# Altium schematic sheet STM32.SchDoc(STM32)
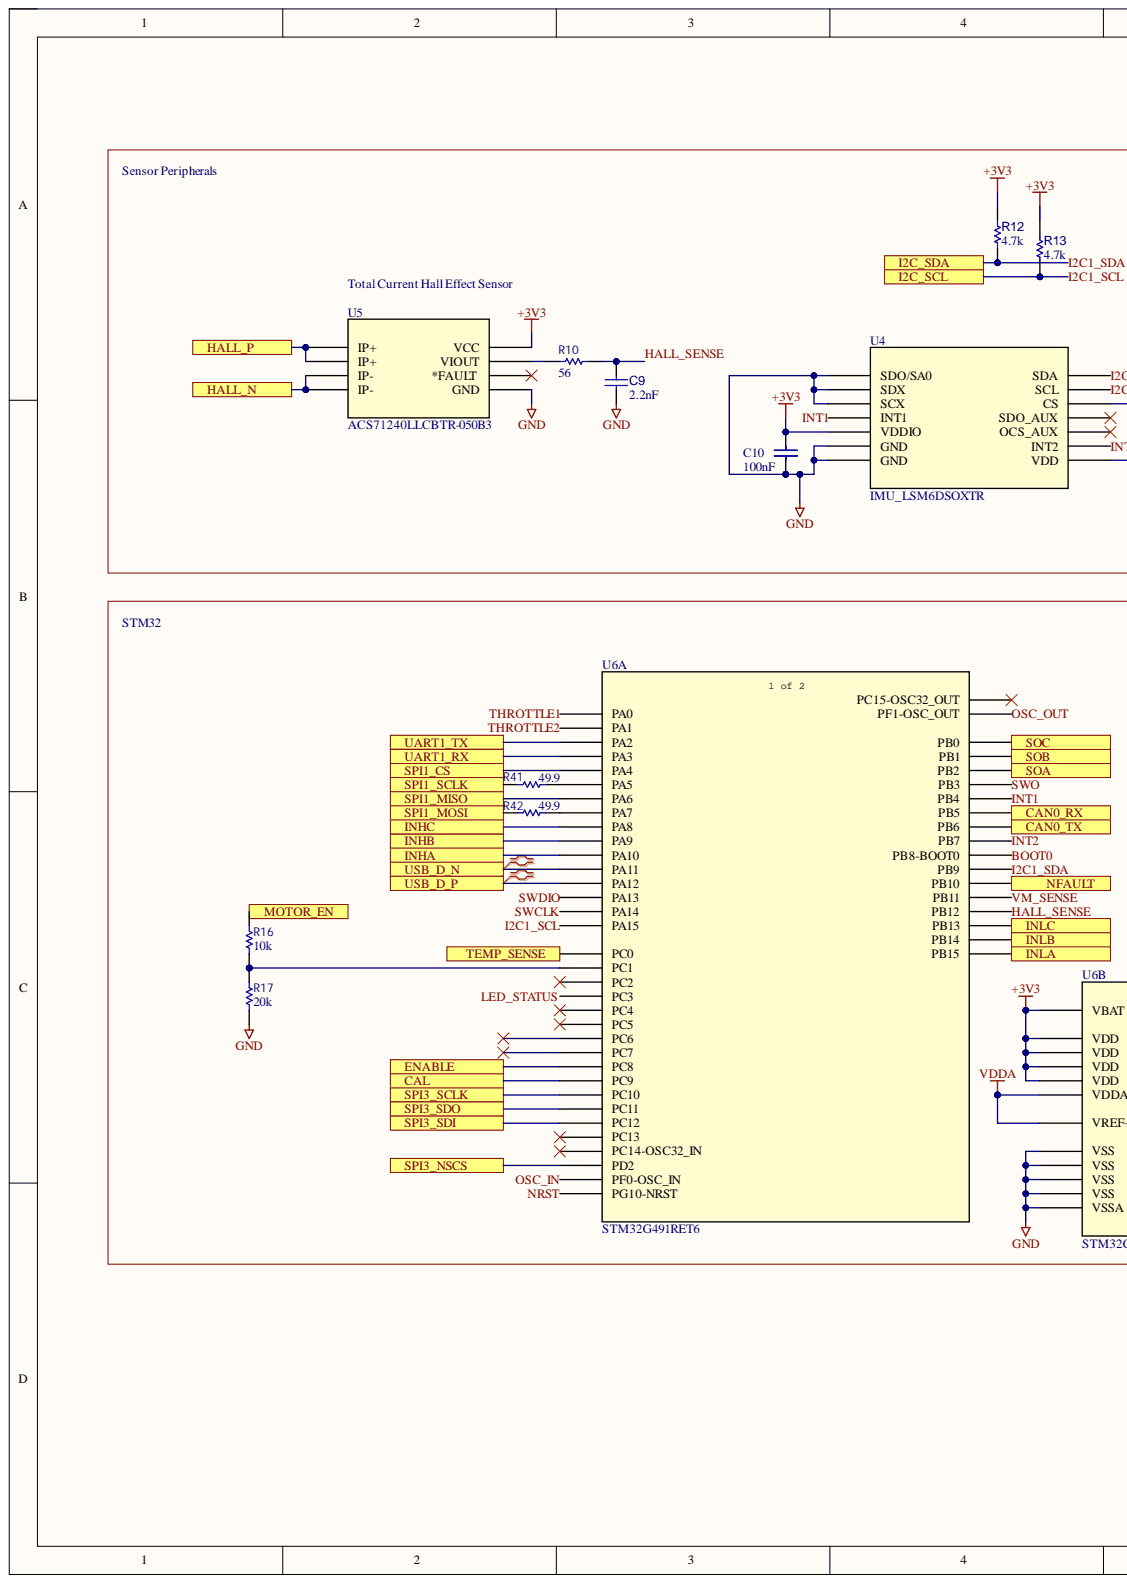
[diagram p0: STM32.SchDoc, left]
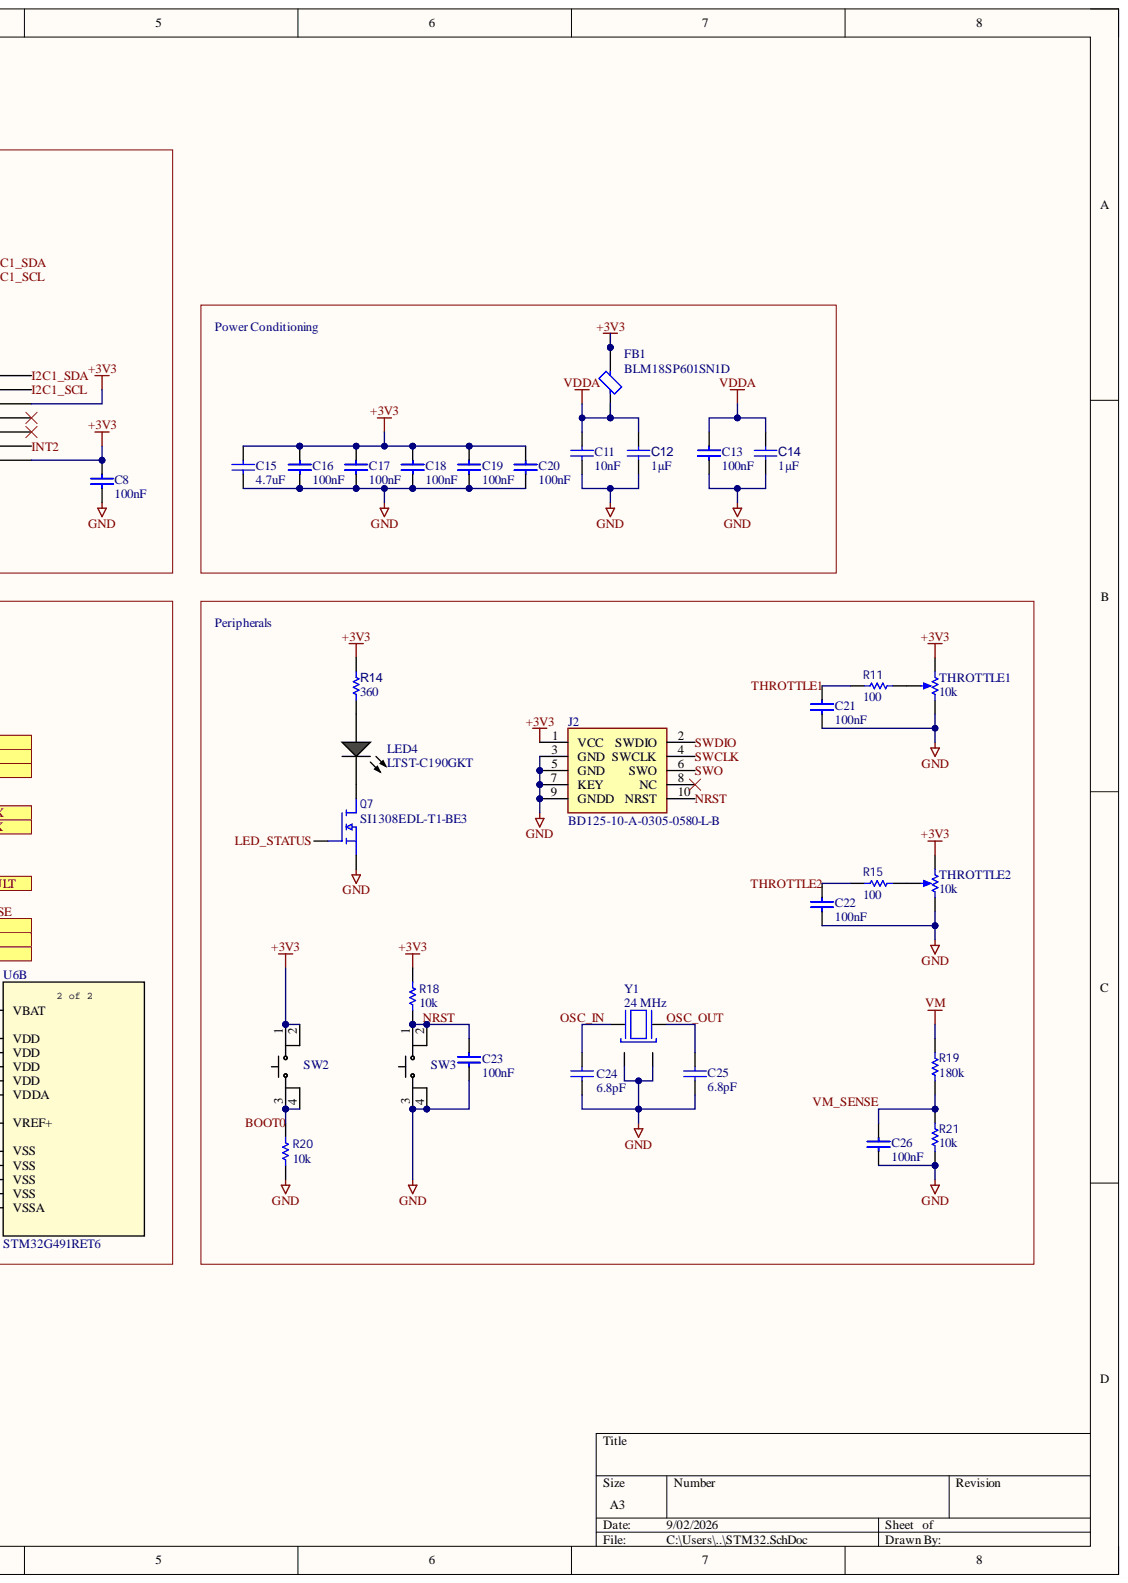
[diagram p1: STM32.SchDoc, right]

## Parts
C8  100nF  [C-0402]
C9  2.2nF  [C-0402]
C10  100nF  [C-0402]
C11  10nF  [C-0402]
C12  1µF  [C-0402]
C13  100nF  [C-0402]
C14  1µF  [C-0402]
C15  4.7uF  [0603_Capacitor]
C16  100nF  [C-0402]
C17  100nF  [C-0402]
C18  100nF  [C-0402]
C19  100nF  [C-0402]
C20  100nF  [C-0402]
C21  100nF  [C-0402]
C22  100nF  [C-0402]
C23  100nF  [C-0402]
C24  6.8pF  [C-0402]
C25  6.8pF  [C-0402]
C26  100nF  [C-0402]
FB1  BLM18SP601SN1D  [0603_Capacitor]
J2  BD125-10-A-0305-0580-L-B  [GCT_BD125-10-A-0305-0580-L-B]
LED4  LTST-C190GKT  [LTST-C190GKT_V]
Q7  value SI1308EDL-T1-BE3  Manufacturer PN: SI1308EDL-T1-BE3  [SC70_AOS]  (hidden comment: Transistor MOSFET SI1308EDL-T1-BE3)
R10  value 56  [R-0402]  (hidden comment: Resistor 56)
R11  value 100  [R-0402]  (hidden comment: Resistor 100)
R12  value 4.7k  [R-0402]  (hidden comment: Resistor 4.7k)
R13  value 4.7k  [R-0402]  (hidden comment: Resistor 4.7k)
R14  360  [R-0402]
R15  value 100  [R-0402]  (hidden comment: Resistor 100)
R16  value 10k  [R-0402]  (hidden comment: Resistor 10k)
R17  value 20k  [R-0402]  (hidden comment: Resistor 20k)
R18  value 10k  [R-0402]  (hidden comment: Resistor 10k)
R19  value 180k  [R-0402]  (hidden comment: Resistor 180k)
R20  value 10k  [R-0402]  (hidden comment: Resistor 10k)
R21  value 10k  [R-0402]  (hidden comment: Resistor 10k)
R41  value 49.9  [R-0402]  (hidden comment: Resistor 49.9)
R42  value 49.9  [R-0402]  (hidden comment: Resistor 49.9)
SW2  =Value  [SW_SKRPACE010_AAL]
SW3  =Value  [SW_SKRPACE010_AAL]
THROTTLE1  10k  [BOUR-3362P]
THROTTLE2  10k  [BOUR-3362P]
U4  IMU_LSM6DSOXTR  [LGA-14L_STM]
U5  ACS71240LLCBTR-050B3  Type: Hall Effect Sensor  [SOIC8_LCB_ALM]
U6  STM32G491RET6  [LQFP64_10X10_STM]  (parts A,B)
Y1  24 MHz  [OSC_NX2016SA-24MHZ-EXS00A-CS10820]

## Ports
CAL, CAN0_RX, CAN0_TX, ENABLE, HALL_N, HALL_P, I2C_SCL, I2C_SDA, INHA, INHB, INHC, INLA, INLB, INLC, MOTOR_EN, NFAULT, SOA, SOB, SOC, SPI1_CS, SPI1_MISO, SPI1_MOSI, SPI1_SCLK, SPI3_NSCS, SPI3_SCLK, SPI3_SDI, SPI3_SDO, TEMP_SENSE, UART1_RX, UART1_TX, USB_D_N, USB_D_P

## Nets
+3V3: C8.1 C10.1 C15.1 C16.1 C17.1 C18.1 C19.1 C20.1 FB1.2 J2.1(VCC) R12.2 R13.2 R14.2 R18.2 SW2.1 SW2.2 THROTTLE1.1 THROTTLE2.1 U4.5(VDDIO) U4.8(VDD) U4.12(CS) U5.8(VCC) U6.1(VBAT) U6.16(VDD) U6.32(VDD) U6.48(VDD) U6.64(VDD)
GND: C8.2 C9.1 C10.2 C11.2 C12.1 C13.2 C14.1 C15.2 C16.2 C17.2 C18.2 C19.2 C20.2 C21.2 C22.2 C23.2 C24.1 C25.1 C26.2 J2.3(GND) J2.5(GND) J2.7(KEY) J2.9(GNDD) Q7.2 R17.1 R20.1 R21.1 SW3.3 SW3.4 THROTTLE1.3 THROTTLE2.3 U4.1(SDO/SA0) U4.2(SDX) U4.3(SCX) U4.6(GND) U4.7(GND) U5.5(GND) U6.15(VSS) U6.27(VSSA) U6.31(VSS) U6.47(VSS) U6.63(VSS) Y1.2 Y1.4
VDDA: C11.1 C12.2 C13.1 C14.2 FB1.1 U6.28(VREF+) U6.29(VDDA)
VM: R19.2
BOOT0: R20.2 SW2.3 SW2.4 U6.61(PB8-BOOT0)
CAL: U6.41(PC9) ; port CAL
CAN0_RX: U6.58(PB5) ; port CAN0_RX
CAN0_TX: U6.59(PB6) ; port CAN0_TX
ENABLE: U6.40(PC8) ; port ENABLE
HALL_N: U5.3(IP-) U5.4(IP-) ; port HALL_N
HALL_P: U5.1(IP+) U5.2(IP+) ; port HALL_P
HALL_SENSE: C9.2 R10.2 U6.34(PB12)
I2C1_SCL: R13.1 U4.13(SCL) U6.51(PA15) ; port I2C_SCL
I2C1_SDA: R12.1 U4.14(SDA) U6.62(PB9) ; port I2C_SDA
INHA: U6.44(PA10) ; port INHA
INHB: U6.43(PA9) ; port INHB
INHC: U6.42(PA8) ; port INHC
INLA: U6.37(PB15) ; port INLA
INLB: U6.36(PB14) ; port INLB
INLC: U6.35(PB13) ; port INLC
INT1: U4.4(INT1) U6.57(PB4)
INT2: U4.9(INT2) U6.60(PB7)
LED_STATUS: Q7.1 U6.11(PC3)
MOTOR_EN: R16.2 ; port MOTOR_EN
NFAULT: U6.30(PB10) ; port NFAULT
NRST: C23.1 J2.10(NRST) R18.1 SW3.1 SW3.2 U6.7(PG10-NRST)
OSC_IN: C24.2 U6.5(PF0-OSC_IN) Y1.1
OSC_OUT: C25.2 U6.6(PF1-OSC_OUT) Y1.3
SOA: U6.26(PB2) ; port SOA
SOB: U6.25(PB1) ; port SOB
SOC: U6.24(PB0) ; port SOC
SPI1_CS: U6.18(PA4) ; port SPI1_CS
SPI1_MISO: U6.20(PA6) ; port SPI1_MISO
SPI1_MOSI: R42.1 ; port SPI1_MOSI
SPI1_SCLK: R41.1 ; port SPI1_SCLK
SPI3_NSCS: U6.55(PD2) ; port SPI3_NSCS
SPI3_SCLK: U6.52(PC10) ; port SPI3_SCLK
SPI3_SDI: U6.54(PC12) ; port SPI3_SDI
SPI3_SDO: U6.53(PC11) ; port SPI3_SDO
SWCLK: J2.4(SWCLK) U6.50(PA14)
SWDIO: J2.2(SWDIO) U6.49(PA13)
SWO: J2.6(SWO) U6.56(PB3)
TEMP_SENSE: U6.8(PC0) ; port TEMP_SENSE
THROTTLE1: C21.1 R11.1 U6.12(PA0)
THROTTLE2: C22.1 R15.1 U6.13(PA1)
UART1_RX: U6.17(PA3) ; port UART1_RX
UART1_TX: U6.14(PA2) ; port UART1_TX
USB_D_N: U6.45(PA11) ; port USB_D_N
USB_D_P: U6.46(PA12) ; port USB_D_P
VM_SENSE: C26.1 R19.1 R21.2 U6.33(PB11)
NetLED4_1: LED4.1 R14.1
NetLED4_2: LED4.2 Q7.3
NetR10_1: R10.1 U5.7(VIOUT)
NetR11_2: R11.2 THROTTLE1.2
NetR15_2: R15.2 THROTTLE2.2
NetR16_1: R16.1 R17.2 U6.9(PC1)
NetR41_2: R41.2 U6.19(PA5)
NetR42_2: R42.2 U6.21(PA7)

Not connected: J2 8(NC); U4 10(OCS_AUX) 11(SDO_AUX); U5 6(*FAULT); U6 2(PC13) 3(PC14-OSC32_IN) 4(PC15-OSC32_OUT) 10(PC2) 22(PC4) 23(PC5) 38(PC6) 39(PC7)

## Library data of the parts
C8 C10 C13 C16-C23 C26 = CMP-001-00203-1: 0.1 µF ±10% 50V Ceramic Capacitor X7R 0402 (1005 Metric); Manufacturer: Murata; Manufacturer PN: GCM155R71H104KE02J; Voltage Rating: 50; Component Kind: Standard; ComponentLink1Description: Datasheet; ComponentLink1URL: https://www.mouser.com/datasheet/3/508/1/KEM_C1002_X7R_SMD.pdf; Library Name: BLDC_Symbols.SCHLIB; Package: 0402; Supplier 1: Digikey; Supplier Part Number 1: GCM155R71H104KE02J; Tolerance: 10%; Type: Capacitor X7R; Value: 100nF
C9 = CMP-001-00243-1: Ceramic Capacitor, Multilayer, Ceramic, 25V, 10% +Tol, 10% -Tol, C0G, 30ppm/Cel TC, 0.0022uF, Surface Mount, 0402; Manufacturer: KEMET; Manufacturer PN: C0402C222F3GECTU; Tolerance: 1%; Type: Capacitor C0G/NP0; Component Kind: Standard; ComponentLink1Description: Datasheet; ComponentLink1URL: https://search.murata.co.jp/Ceramy/image/img/A01X/G101/ENG/GRM188R71C222KA01-01.pdf; Library Name: BLDC_Symbols.SchLib; Package: 0402; Supplier 1: Digikey; Supplier Part Number 1: 399-C0402C222F3GECTUCT-ND; Value: 2.2nF; Voltage Rating: 25V
C11 = CMP-001-00204-1: 10000 pF ±10% 50V Ceramic Capacitor X7R 0402 (1005 Metric); Manufacturer: Murata Electronics; Manufacturer PN: GCM155R71H103KA55D; Voltage Rating: 100V; Component Kind: Standard; ComponentLink1Description: Datasheet; ComponentLink1URL: https://www.vishay.com/docs/45199/vjcommercialseries.pdf; Library Name: BLDC_Symbols.SchLib; Package: 0402; Supplier 1: Digikey; Supplier Part Number 1: 490-4762-1-ND; Tolerance: 10%; Type: Capacitor X7R; Value: 10nF
C12 C14 = CAP, CERAMIC 1uF 0402 25V X5R: 1 µF ±10% 25V Ceramic Capacitor X5R 0402 (1005 Metric); Manufacturer: Murata Electronics; Manufacturer PN: GRM155R61E105KA12D; Component Kind: Standard; ComponentLink1Description: Datasheet; ComponentLink1URL: https://mm.digikey.com/Volume0/opasdata/d220001/medias/docus/3644/CL10B105KA8NNNC_spec.pdf; Library Name: BLDC_Symbols.SchLib; Package: 0402; Supplier 1: Digikey; Supplier Part Number 1: 490-10017-1-ND; Tolerance: 10%; Type: Capacitor X5R; Value: 1µF; Voltage Rating: 25V
C15 = CMP-001-00206-2: Ceramic Capacitor, YES, Ceramic, 16V, 10% +Tol, 10% -Tol, X7S, 22% TC, 4.7uF, Surface Mount, 1608M/0603; Manufacturer: Murata Electronics; Manufacturer PN: GRM188C71C475KE21J; Type: Capacitor X7S; Component Kind: Standard; ComponentLink1Description: Datasheet; ComponentLink1URL: https://search.murata.co.jp/Ceramy/image/img/A01X/G101/ENG/GRM188C71C475KE21-01A.pdf; Library Name: BLDC_Symbols.SchLib; Package: 0603; Supplier 1: Digikey; Supplier Part Number 1: 490-GRM188C71C475KE21JCT-ND; Tolerance: 10%; Value: 4.7uF; Voltage Rating: 16V
C24 C25 = CMP-001-00236-2: 6.8 pF ±0.05pF 50V Ceramic Capacitor C0G, NP0 0402 (1005 Metric); Manufacturer: Murata Electronics; Manufacturer PN: GJM1555C1H6R8WB01D; Component Kind: Standard; ComponentLink1Description: Datasheet; ComponentLink1URL: https://yageogroup.com/content/datasheet/asset/file/KEM_C1003_C0G_SMD; Library Name: BLDC_Symbols.SchLib; Package: 0402; Supplier 1: Digikey; Supplier Part Number 1: 490-14447-1-ND; Tolerance: 0.05pF; Type: Capacitor C0G; Value: 6.8pF; Voltage Rating: 50V
FB1 = CMP-006-00047-1: Ferrite Beads Multi-Layer Power 600Ohm 25% 100MHz 1.5A 0.14Ohm DCR 0603 T/R; Manufacturer: Murata Electronics; DCR: 140m; ESR: 0.14; Component Kind: Standard; ComponentLink1Description: Datasheet; ComponentLink1URL: https://datasheet.octopart.com:443/BLM18SP601SN1D-Murata-datasheet-180806942.pdf?src-supplier=IHS; Current: 1.5A; Library Name: BLDC_Symbols.SchLib; Manufacturer PN: BLM18SP601SN1D; Package: 0603; Supplier 1: Digikey; Supplier Part Number 1: 490-BLM18SP601SN1DCT-ND; Tolerance: 25%; Type: Ferrite Bead; Value: BLM18SP601SN1D
J2 = HEADER, HEADER DUAL 1.27MM VERT SMT 10PO: Connector Header Surface Mount 10 position 0.050" (1.27mm); Manufacturer: GCT; Component Kind: Standard; ComponentLink1Description: Datasheet; Library Name: BLDC_Symbols.SchLib; Manufacturer PN: BD125-10-A-0305-0580-L-B; Supplier 1: Digikey; Supplier Part Number 1: 2073-BD125-10-A-0305-0580-L-BCT-ND; Type: Header; Value: BD125-10-A-0305-0580-L-B
LED4 = CMP-007-00022-2: LED Uni-Color Green, 100 mW, 30 mA, -55 to 85 degC, 2-Pin SMD, RoHS, Tape and Reel; Manufacturer: Lite-On Inc.; Current: 30mA; Power: 100mW; Component Kind: Standard; ComponentLink1Description: Datasheet; ComponentLink1URL: https://mm.digikey.com/Volume0/opasdata/d220001/medias/docus/858/LTST-C190GKT.pdf; Library Name: BLDC_Symbols.SchLib; Manufacturer PN: LTST-C190GKT; Package: 0603; Supplier 1: Digikey; Supplier Part Number 1: 160-LTST-C190GKTCT-ND; Type: LED; Value: LTST-C190GKT
Q7 = Transistor MOSFET SI1308EDL-T1-BE3: N-Channel 30 V 1.4A (Tc) 400mW (Ta), 500mW (Tc) Surface Mount SC-70-3; Manufacturer: Vishay Siliconix; Current: 1.4; DCR: 132m; Power: 400W; Voltage Rating: 30; Component Kind: Standard; ComponentLink1Description: Datasheet; Library Name: BLDC_Symbols.SchLib; Package: SOT-323; Supplier 1: Digikey; Supplier Part Number 1: 742-SI1308EDL-T1-BE3CT-ND; Type: Transistor MOSFET
R10 = Resistor 56: 56 Ohms ±5% 0.125W, 1/8W Chip Resistor 0402 (1005 Metric) Automotive AEC-Q200, Moisture Resistant Thick Film; Manufacturer: YAGEO; Manufacturer PN: AC0402JR-7W56RL; Power: 0.125; Component Kind: Standard; ComponentLink1Description: Datasheet; Library Name: BLDC_Symbols.SchLib; Package: 0402; Supplier 1: Digikey; Supplier Part Number 1: 13-AC0402JR-7W56RLCT-ND; Tolerance: 5%; Type: Resistor
R11 R15 = Resistor 100: 100 Ohms ±1% 0.2W, 1/5W Chip Resistor 0402 (1005 Metric) Anti-Sulfur, Automotive AEC-Q200, Pulse Withstanding Thick Film; Manufacturer: YAGEO; Manufacturer PN: RCA0402100RFKEDHP; Power: 0.2; Component Kind: Standard; ComponentLink1Description: Datasheet; Library Name: BLDC_Symbols.SchLib; Package: 0402; Supplier 1: Digikey; Supplier Part Number 1: 541-3239-1-ND; Tolerance: 1%; Type: Resistor
R12 R13 = Resistor 4.7k: 4.7 kOhms ±5% 0.063W, 1/16W Chip Resistor 0402 (1005 Metric) Moisture Resistant Thick Film; Manufacturer: YAGEO; Manufacturer PN: RC0402JR-074K7L; Power: 0.0625W; Component Kind: Standard; ComponentLink1Description: Datasheet; Library Name: BLDC_Symbols.SchLib; Package: 0402; Supplier 1: Digikey; Supplier Part Number 1: 311-4.7KJRCT-ND; Tolerance: 5%; Type: Resistor
R14 = RES, 360 OHM 1% 1/16W 0402: 360 Ohms ±1% 0.063W, 1/16W Chip Resistor 0402 (1005 Metric) Moisture Resistant Thick Film; Manufacturer: YAGEO; Manufacturer PN: RC0402FR-13360RL; Power: 0.1W; Component Kind: Standard; ComponentLink1Description: Datasheet; Library Name: BLDC_Symbols.SCHLIB; Package: 0402; Supplier 1: Digikey; Supplier Part Number 1: 13-RC0402FR-13360RLCT-ND; Tolerance: 1%; Type: Resistor; Value: 360
R16 R18 R20 R21 = Resistor 10k: 10 kOhms ±5% 0.063W, 1/16W Chip Resistor 0402 (1005 Metric) Moisture Resistant Thick Film; Manufacturer: YAGEO; Manufacturer PN: RC0402JR-0710KL; Power: 0.0625; Component Kind: Standard; ComponentLink1Description: Datasheet; Library Name: BLDC_Symbols.SchLib; Package: 0402; Supplier 1: Digikey; Supplier Part Number 1: 311-10KJRCT-ND; Tolerance: 5%; Type: Resistor
R17 = Resistor 20k: 20 kOhms ±1% 0.063W, 1/16W Chip Resistor 0402 (1005 Metric) Moisture Resistant Thick Film; Manufacturer: YAGEO; Manufacturer PN: RC0402FR-0720KL; Power: 0.0625; Component Kind: Standard; ComponentLink1Description: Datasheet; Library Name: BLDC_Symbols.SchLib; Package: 0402; Supplier 1: Digikey; Supplier Part Number 1: 311-20.0KLRCT-ND; Tolerance: 1%; Type: Resistor
R19 = Resistor 180k: 180 kOhms ±1% 0.063W, 1/16W Chip Resistor 0402 (1005 Metric) Moisture Resistant Thick Film; Manufacturer: YAGEO; Manufacturer PN: RC0402FR-07180KL; Power: 0.0625; Component Kind: Standard; ComponentLink1Description: Datasheet; Library Name: BLDC_Symbols.SchLib; Package: 0402; Supplier 1: Digikey; Supplier Part Number 1: 311-180KLRCT-ND; Tolerance: 1%; Type: Resistor
R41 R42 = Resistor 49.9: 49.9 Ohms ±1% 0.063W, 1/16W Chip Resistor 0402 (1005 Metric) Moisture Resistant Thick Film; Manufacturer: YAGEO; Manufacturer PN: RC0402FR-0749R9L; Power: 0.0625; Component Kind: Standard; ComponentLink1Description: Datasheet; Library Name: BLDC_Symbols.SchLib; Package: 0402; Supplier 1: Digikey; Supplier Part Number 1: 311-49.9LRCT-ND; Tolerance: 1%; Type: Resistor
SW2 SW3 = SWITCH TACTILE SPST-NO 0.05A 16V: Tactile Switch SPST-NO Top Actuated Surface Mount; Manufacturer: Alps Alpine; Manufacturer PN: SKRPACE010; Tolerance: 5%; Voltage Rating: 16V; Component Kind: Standard; ComponentLink1Description: Datasheet; ComponentLink1URL: https://www.mouser.com/datasheet/3/508/1/KEM_C1002_X7R_SMD.pdf; Library Name: BLDC_Symbols.SCHLIB; Supplier 1: Digikey; Supplier Part Number 1: 4809-SKRPACE010CT-ND; Type: Switch tactile
THROTTLE1 THROTTLE2 = CMP-009-00039-2: Square Trimpot(R) Trimming Potentiometer, 10 KOhm, 300 V, -55 to 125 degC, 3-Pin THD, RoHS, Tube; Manufacturer: Bourns; Manufacturer PN: 3362P-1-103LF; Power: 500mW; Tolerance: 10%; Voltage Rating: 300V; Component Kind: Standard; ComponentLink1Description: Datasheet; Library Name: BLDC_Symbols.SchLib; Package: TH; Supplier 1: Mouser; Supplier Part Number 1: 652-3362P-1-103LF; Type: Potentiometer; Value: 10k
U4 = CMP-857-000000-1: LSM6DSOX: 3.6 V 3 Axis Accelerometer Gyroscope iNEMO Inertial Module - LGA-14L; Manufacturer: STMicroelectronics; Voltage Rating: 3.6V; Component Kind: Standard; ComponentLink1Description: Datasheet; ComponentLink1URL: https://datasheet.octopart.com/LSM6DSOXTR-STMicroelectronics-datasheet-134836096.pdf?src-supplier=ODG+(Origin+Data+Global); Library Name: BLDC_Symbols.SchLib; Manufacturer PN: LSM6DSOXTR; Package: LGA; Supplier 1: Mouser; Supplier Part Number 1: 511-LSM6DSOXTR; Type: IMU Sensor; Value: IMU_LSM6DSOXTR
U5 = CMP-031-00039-1: Description; Manufacturer: Allegro Microsystems; Current: 45A; Voltage Rating: 6V; Component Kind: Standard; ComponentLink1Description: Datasheet; ComponentLink1URL: https://www.allegromicro.com/~/media/files/datasheets/acs71240-datasheet.pdf; Library Name: BLDC_Symbols.SchLib; Manufacturer PN: ACS71240LLCBTR-050B3; Package: SOIC; Supplier 1: Digikey; Supplier Part Number 1: 620-ACS71240LLCBTR-050B3CT-ND; Value: ACS71240LLCBTR-050B3
U6 = CMP-031-00035-1: Mainstream Arm Cortex-M4 MCU 170 MHz with 512 Kbytes of Flash memory, Math Accelerator, High Analog level integration; Manufacturer: STMicroelectronics; Component Kind: Standard; ComponentLink1Description: Datasheet; ComponentLink1URL: https://www.st.com/resource/en/datasheet/stm32g491cc.pdf; Library Name: BLDC_Symbols.SchLib; Manufacturer PN: STM32G491RET6; Package: QFP; Supplier 1: Mouser; Supplier Part Number 1: 511-STM32G491RET6; Type: MCU; Value: STM32G491RET6
Y1 = CMP-003-00030-3: 24 MHz Â±15ppm Crystal 8pF 80 Ohms 4-SMD, No Lead; Manufacturer: NDK (NIHON DEMPA KOGYO CO., LTD); Manufacturer PN: CS10820-24M; Component Kind: Standard; ComponentLink1Description: Datasheet; ComponentLink1URL: https://mm.digikey.com/Volume0/opasdata/d220001/medias/docus/7847/EXS00A-CS10820.pdf; Library Name: BLDC_Symbols.SchLib; Package: QFN; Supplier 1: Digikey; Supplier Part Number 1: 644-CS10820-24MCT-ND; Type: Crystal Resonator; Value: 24 MHz
